# Altium schematic sheet Devices.SchDoc(Devices)
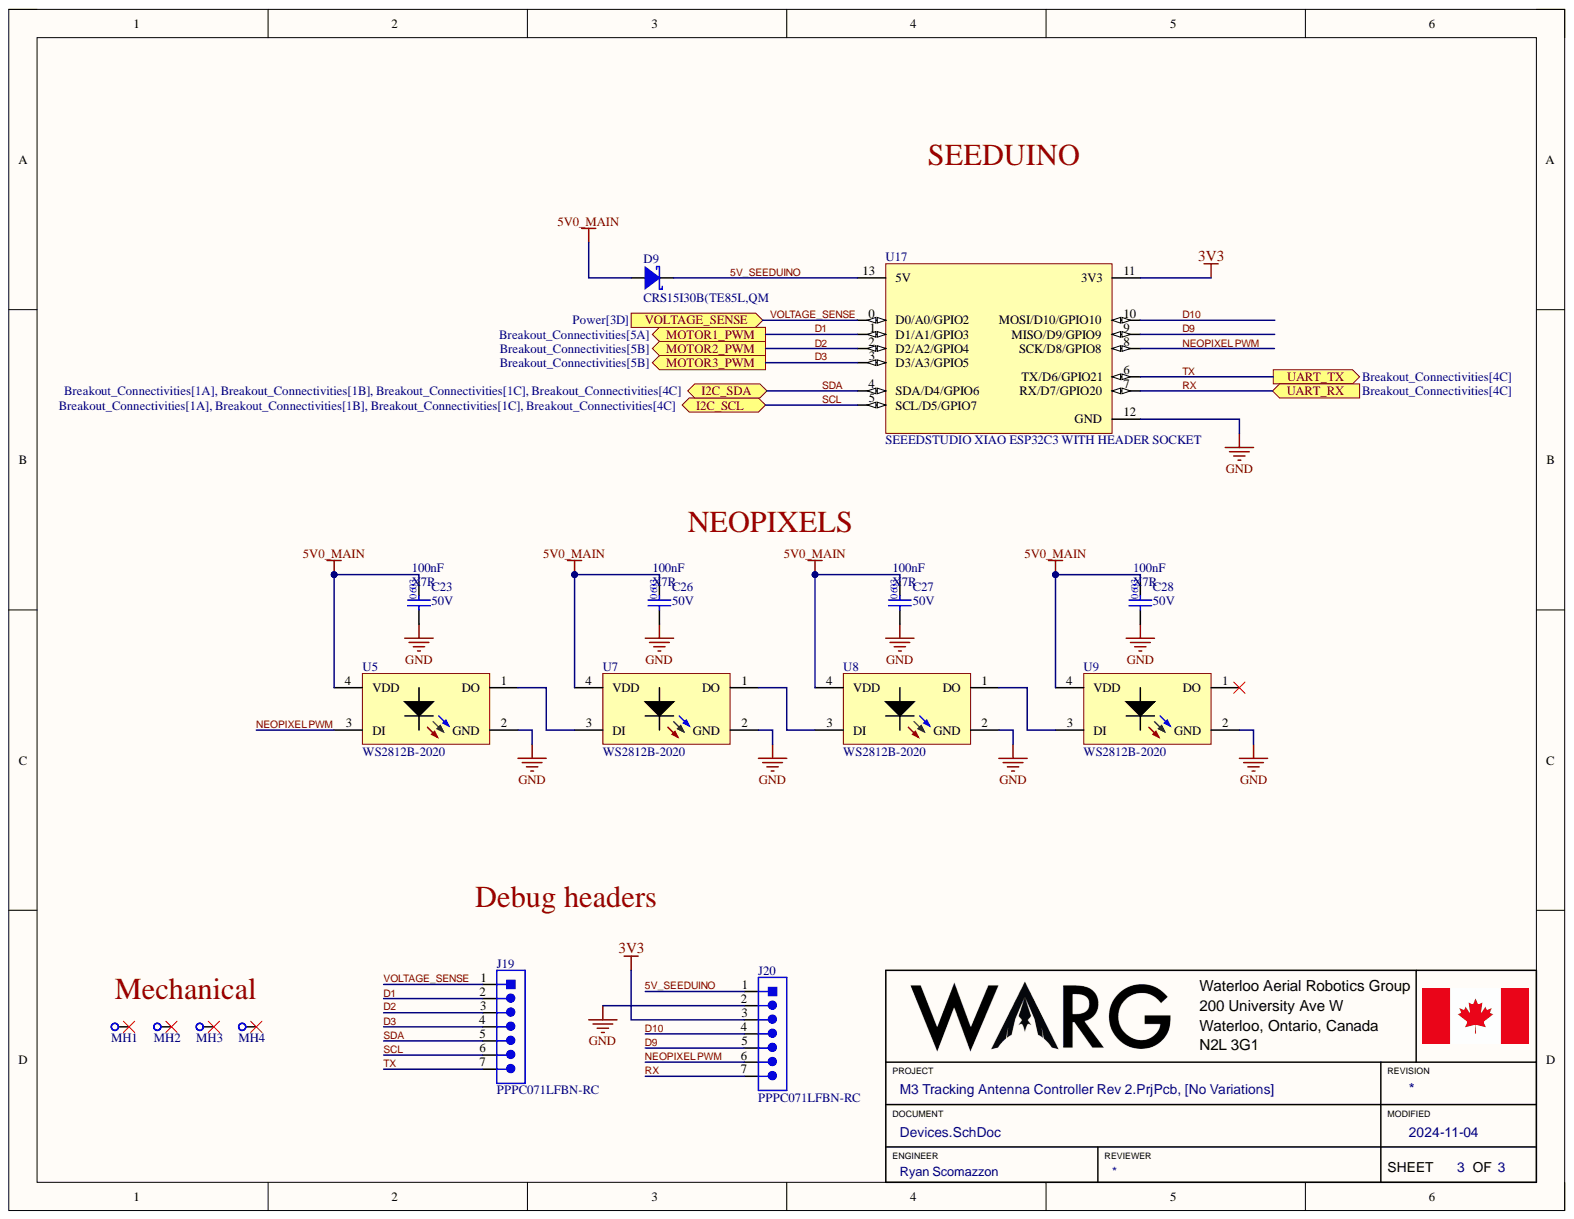
[diagram p0: Devices.SchDoc]

## Parts
C23  value 100nF  Temperature Coefficient: X7R  Voltage Rating: 50V  [.CAP_0603-Footprint-1]  (hidden comment: CGA3E2X7R1H104K080AA)
C26  value 100nF  Temperature Coefficient: X7R  Voltage Rating: 50V  [.CAP_0603-Footprint-1]  (hidden comment: CGA3E2X7R1H104K080AA)
C27  value 100nF  Temperature Coefficient: X7R  Voltage Rating: 50V  [.CAP_0603-Footprint-1]  (hidden comment: CGA3E2X7R1H104K080AA)
C28  value 100nF  Temperature Coefficient: X7R  Voltage Rating: 50V  [.CAP_0603-Footprint-1]  (hidden comment: CGA3E2X7R1H104K080AA)
D9  CRS15I30B(TE85L,QM  [.SCHOTTKY-Footprint-1]
J19  PPPC071LFBN-RC  [PPPC071LFBN-RC-Footprint-1]
J20  PPPC071LFBN-RC  [PPPC071LFBN-RC-Footprint-1]
MH1  M3HOLE-STANDARD  [M3HOLE-STANDARD-Footprint-1]  (value not drawn)
MH2  M3HOLE-STANDARD  [M3HOLE-STANDARD-Footprint-1]  (value not drawn)
MH3  M3HOLE-STANDARD  [M3HOLE-STANDARD-Footprint-1]  (value not drawn)
MH4  M3HOLE-STANDARD  [M3HOLE-STANDARD-Footprint-1]  (value not drawn)
U5  WS2812B-2020  [WS2812B-2020-Footprint-1]
U7  WS2812B-2020  [WS2812B-2020-Footprint-1]
U8  WS2812B-2020  [WS2812B-2020-Footprint-1]
U9  WS2812B-2020  [WS2812B-2020-Footprint-1]
U17  SEEEDSTUDIO XIAO ESP32C3 WITH HEADER SOCKET  Supply Voltage: 5V  [Seeed Studio XIAO SAMD21 Header Socket-Footprint-1]

## Ports
I2C_SCL, I2C_SDA, MOTOR1_PWM, MOTOR2_PWM, MOTOR3_PWM, UART_RX, UART_TX, VOLTAGE_SENSE

## Nets
3V3: J20.3 U17.11(3V3)
5V0_MAIN: C23.2 C26.2 C27.2 C28.2 D9.1 U5.4(VDD) U7.4(VDD) U8.4(VDD) U9.4(VDD)
GND: C23.1 C26.1 C27.1 C28.1 J20.2 U5.2(GND) U7.2(GND) U8.2(GND) U9.2(GND) U17.12(GND)
5V_SEEDUINO: D9.2 J20.1 U17.13(5V)
D1: J19.2 U17.1(D1/A1/GPIO3) ; port MOTOR1_PWM
D2: J19.3 U17.2(D2/A2/GPIO4) ; port MOTOR2_PWM
D3: J19.4 U17.3(D3/A3/GPIO5) ; port MOTOR3_PWM
D9: J20.5 U17.9(MISO/D9/GPIO9)
D10: J20.4 U17.10(MOSI/D10/GPIO10)
NEOPIXEL PWM: J20.6 U5.3(DI) U17.8(SCK/D8/GPIO8)
RX: J20.7 U17.7(RX/D7/GPIO20) ; port UART_RX
SCL: J19.6 U17.5(SCL/D5/GPIO7) ; port I2C_SCL
SDA: J19.5 U17.4(SDA/D4/GPIO6) ; port I2C_SDA
TX: J19.7 U17.6(TX/D6/GPIO21) ; port UART_TX
VOLTAGE_SENSE: J19.1 U17.0(D0/A0/GPIO2) ; port VOLTAGE_SENSE
NetU5_1: U5.1(DO) U7.3(DI)
NetU7_1: U7.1(DO) U8.3(DI)
NetU8_1: U8.1(DO) U9.3(DI)

Not connected: MH1 1; MH2 1; MH3 1; MH4 1; U9 1(DO)

## Library data of the parts
C23 C26-C28 = CMP-00227-5: CAP CER 0.1UF 50V X7R 0603; Component Kind: Standard; Library Name: WARG
D9 = CMP-004-00018-1: DIODE SCHOTTKY 30V 1.5A S-FLAT; Forward Voltage: 400mV @ 1.5A; Average Forward Current: 1.5A; Component Kind: Standard; Max reverse voltage: 30V; Package: SOD-123F; Library Name: WARG
J19 J20 = CMP-001-0013-8: CONN HEADER 7X1 2.54MM FEMALE VERT TH; Body Breadth: 0.094inch; Body Depth: 0.334inch; Body Length: 0.72inch; Mating Contact Pitch: 0.1inch / 2.51mm; Number of Contacts: 7; PCB Contact Pattern: RECTANGULAR; Rated Current (Signal): 3A; Rows: 1; Component Kind: Standard; Contact Gender: Female; Terminal Length: 0.125inch; Terminal Pitch: 2.54mm; Library Name: WARG
MH1-MH4 = CMP-00005-4: Standard M3 Mounting hole as defined by mech team, see confluence for reasonning; Hole Diam: 3.4; Plating Diam: 6; Component Kind: Standard; Library Name: WARG
U5 U7-U9 = CMP-00294-4: LED Neopixel 2mm from LCSC; Max Input Voltage: 5.3 V Max; Component Kind: Standard; Library Name: WARG
U17 = CMP-001-0012-4: ESP32-C ESP32-C3 Transceiver; 802.11 b/g/n (Wi-Fi, WiFi, WLAN), Bluetooth® 5.x 2.4GHz Evaluation Board; Component Kind: Standard; ComponentLink1Description: https://mm.digikey.com/Volume0/opasdata/d220001/medias/docus/6209/Seeed%20113991054.pdf; Library Name: WARG
